# Altium schematic sheet 5-Connector.SchDoc(5-Connector)
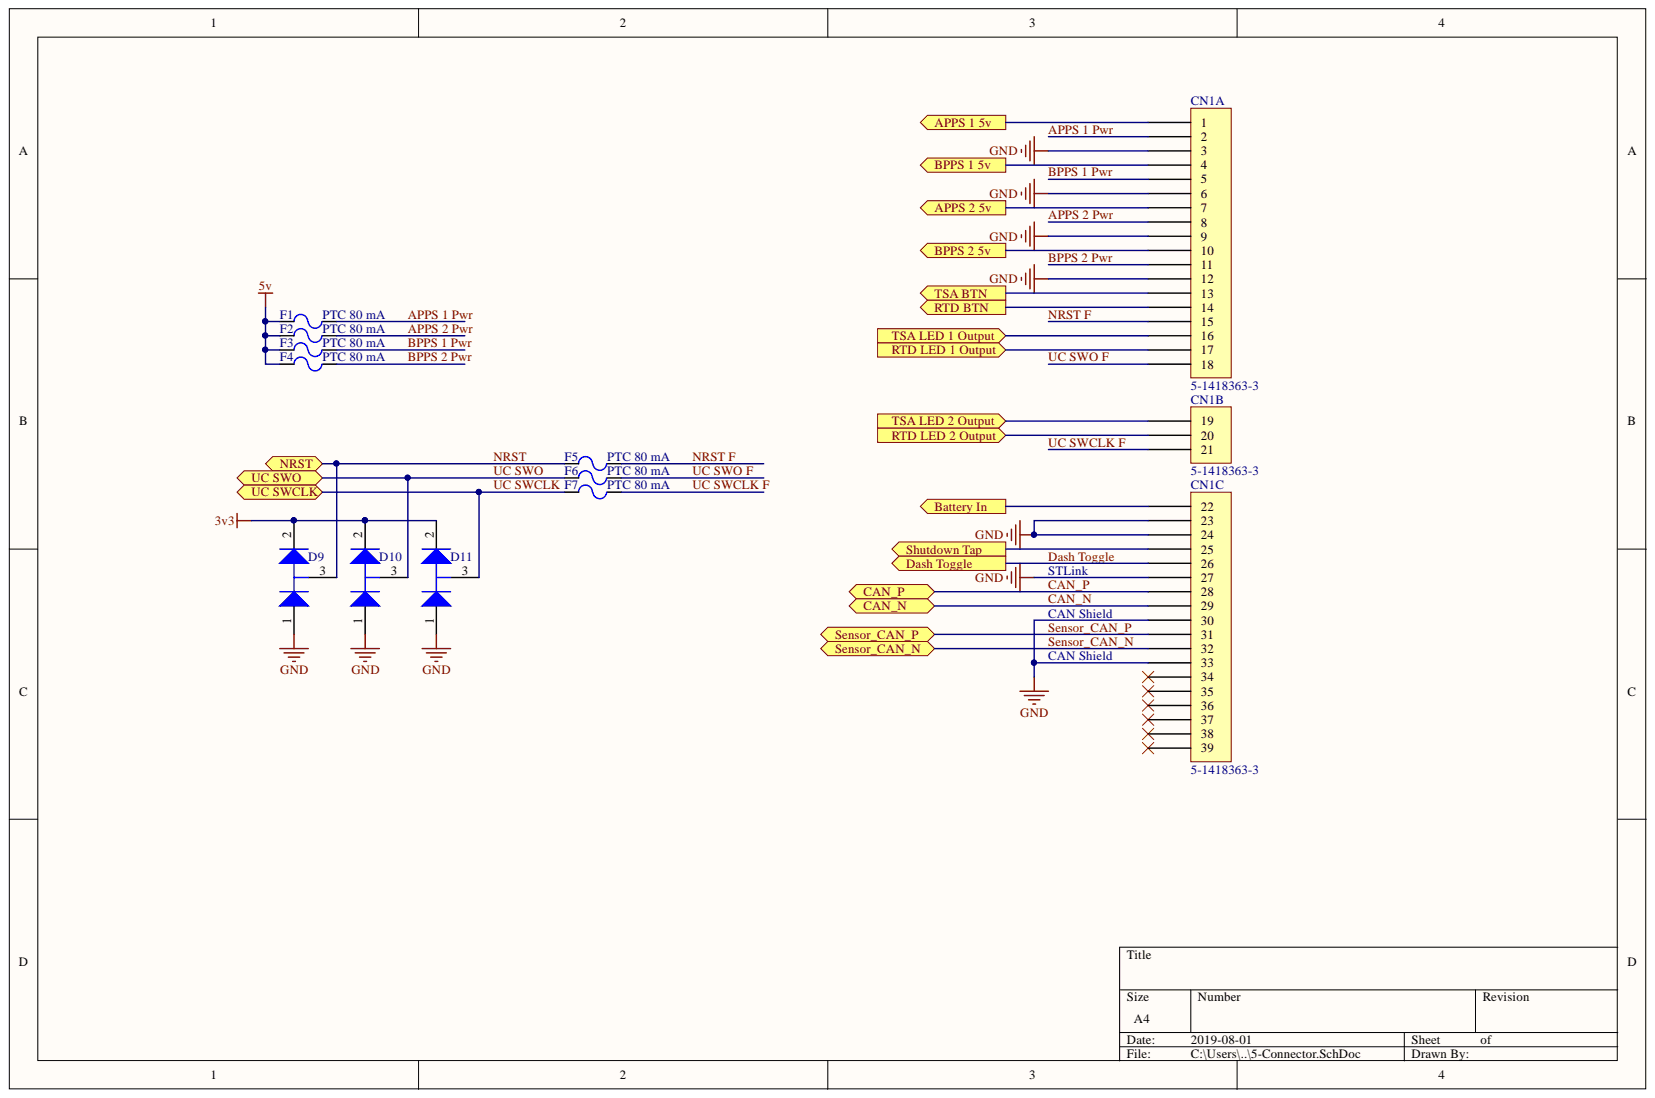
[diagram p0: 5-Connector.SchDoc]

## Parts
CN1  5-1418363-3  [5-1418363-3]  (parts A,B,C)
D9  SZNUP1301ML3T1G  [ONSC-SOT-23-3-318-08_V]  (value not drawn)
D10  SZNUP1301ML3T1G  [ONSC-SOT-23-3-318-08_V]  (value not drawn)
D11  SZNUP1301ML3T1G  [ONSC-SOT-23-3-318-08_V]  (value not drawn)
F1  PTC 80 mA  [0603 fuse]
F2  PTC 80 mA  [0603 fuse]
F3  PTC 80 mA  [0603 fuse]
F4  PTC 80 mA  [0603 fuse]
F5  PTC 80 mA  [0603 fuse]
F6  PTC 80 mA  [0603 fuse]
F7  PTC 80 mA  [0603 fuse]

## Ports
APPS 1 5v, APPS 2 5v, Battery In, BPPS 1 5v, BPPS 2 5v, CAN_N, CAN_P, Dash Toggle, NRST, RTD BTN, RTD LED 1 Output, RTD LED 2 Output, Sensor_CAN_N, Sensor_CAN_P, Shutdown Tap, TSA BTN, TSA LED 1 Output, TSA LED 2 Output, UC SWCLK, UC SWO

## Nets
3v3: D9.2 D10.2 D11.2
5v: F1.1 F2.1 F3.1 F4.1
GND: CN1.3 CN1.6 CN1.9 CN1.12 CN1.23 CN1.24 CN1.27 CN1.30 CN1.33 D9.1 D10.1 D11.1
APPS 1 5v: CN1.1 ; port APPS 1 5v
APPS 1 Pwr: CN1.2 F1.2
APPS 2 5v: CN1.7 ; port APPS 2 5v
APPS 2 Pwr: CN1.8 F2.2
Battery In: CN1.22 ; port Battery In
BPPS 1 5v: CN1.4 ; port BPPS 1 5v
BPPS 1 Pwr: CN1.5 F3.2
BPPS 2 5v: CN1.10 ; port BPPS 2 5v
BPPS 2 Pwr: CN1.11 F4.2
CAN_N: CN1.29 ; port CAN_N
CAN_P: CN1.28 ; port CAN_P
Dash Toggle: CN1.26 ; port Dash Toggle
NRST: D9.3 F5.1 ; port NRST
NRST F: CN1.15 F5.2
RTD BTN: CN1.14 ; port RTD BTN
RTD LED 1 Output: CN1.17 ; port RTD LED 1 Output
RTD LED 2 Output: CN1.20 ; port RTD LED 2 Output
Sensor_CAN_N: CN1.32 ; port Sensor_CAN_N
Sensor_CAN_P: CN1.31 ; port Sensor_CAN_P
Shutdown Tap: CN1.25 ; port Shutdown Tap
TSA BTN: CN1.13 ; port TSA BTN
TSA LED 1 Output: CN1.16 ; port TSA LED 1 Output
TSA LED 2 Output: CN1.19 ; port TSA LED 2 Output
UC SWCLK: D11.3 F7.1 ; port UC SWCLK
UC SWCLK F: CN1.21 F7.2
UC SWO: D10.3 F6.1 ; port UC SWO
UC SWO F: CN1.18 F6.2

Not connected: CN1 34 35 36 37 38 39

## Library data of the parts
CN1 = 5-1418363-3: Levyseal 90 degree 39 pin header; Library Name: VCB20.SchLib; Component Kind: Standard
D9-D11 = CMP-1063-00171-1: Low Capacitance Diode Array for ESD Protection in a Single Data Line, 3-Pin SOT-23, Pb-Free, Tape and Reel; Manufacturer: On Semiconductor; VRWM Max (V): 70; Number of Lines: 1; Direction: Bidirectional; C Max (pF): 0.9; V(BR) Min (V): 70; Supplier Part Number 1: 863-SZNUP1301ML3T1G; Supplier 1: Mouser; RoHS: Pb-Free; PartNumber: SZNUP1301ML3T1G; Packing: Tape and Reel; PackageVersion: Rev. AP, 11/2009; PackageReference: SOT-23-3-318-08; PackageDescription: 3-Pin Small Outline Transistor, Body 2.92 x 1.3 mm, Pitch 0.95 mm; Mounting Technology: Surface Mount; Library Name: Altium Content Vault; DatasheetVersion: Rev. 6; ComponentLink3URL: http://www.onsemi.cn/pub_link/Collateral/318-08.PDF; ComponentLink3Description: Package Specification; ComponentLink2URL: http://www.onsemi.cn/pub_link/Collateral/NUP1301ML3T1-D.PDF; ComponentLink2Description: Datasheet; ComponentLink1URL: http://www.onsemi.com/; ComponentLink1Description: Manufacturer URL; Component Kind: Standard
F1-F7 = PTC fuse 80mA 0ZCM0008FF2G: 0ZCM0008FF2G; Supplier Part Number 1: 507-1817-1-ND; Supplier 1: Digi-Key; Component Kind: Standard; Library Name: VCU Library.SchLib
